# Altium schematic sheet Robot_Sheet1.SchDoc
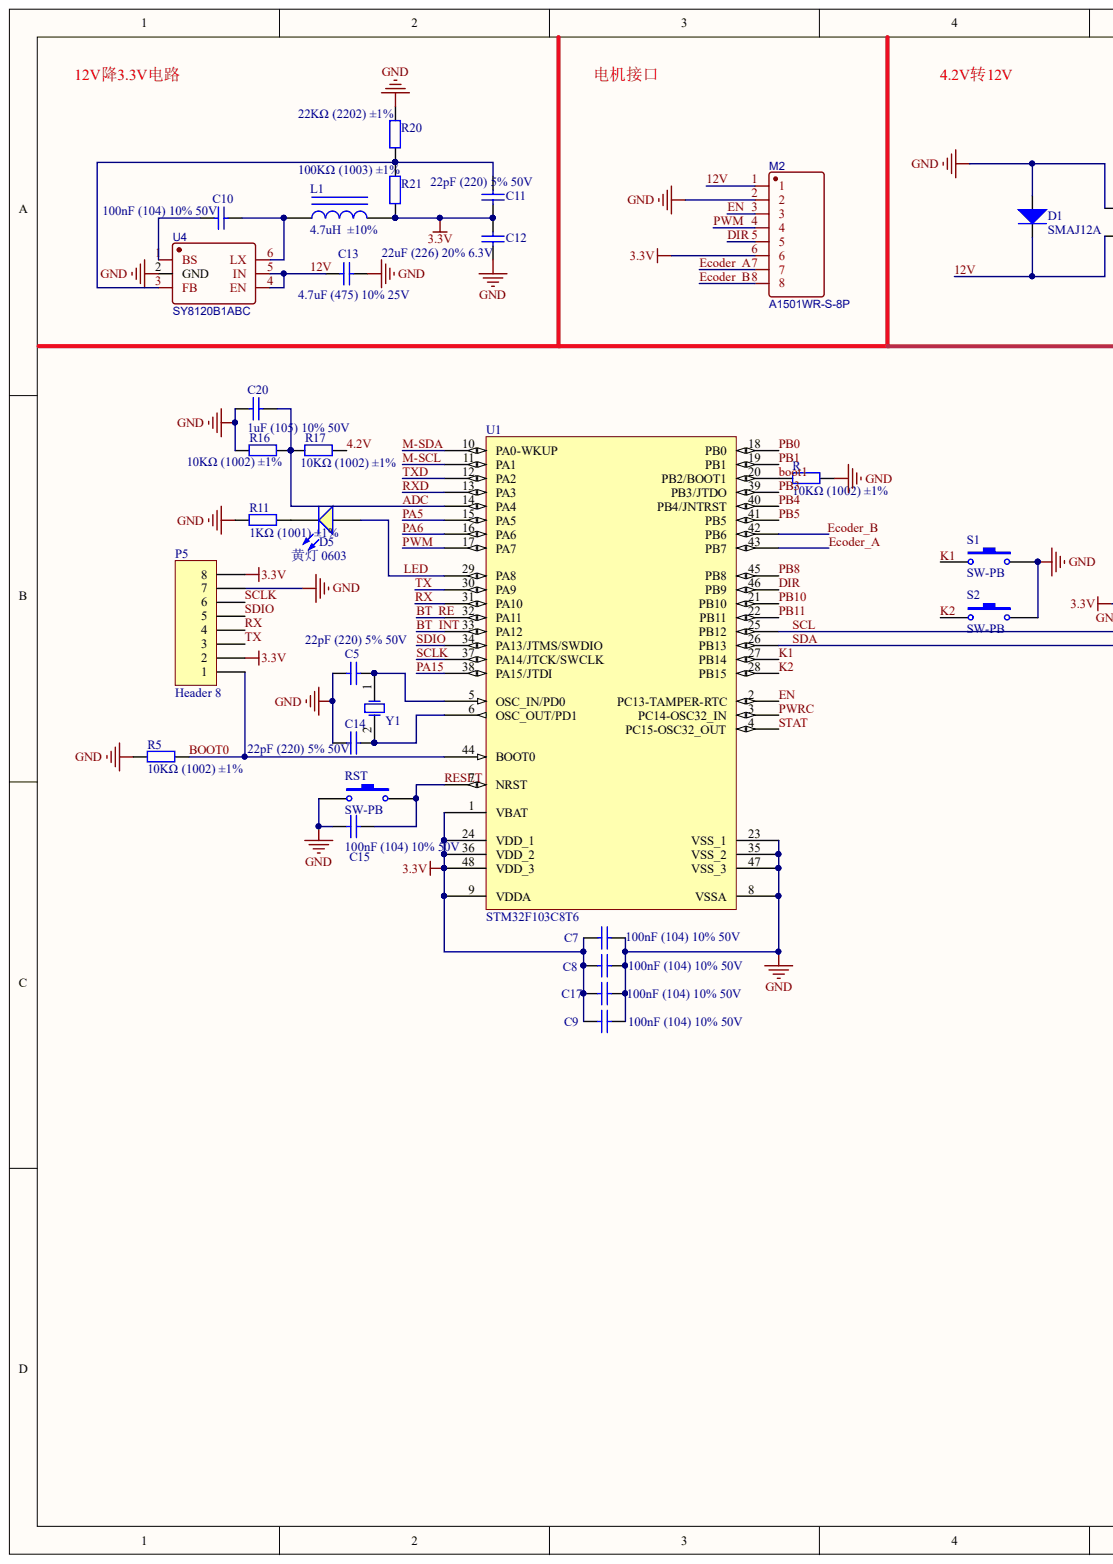
[diagram p0: Robot_Sheet1.SchDoc, left]
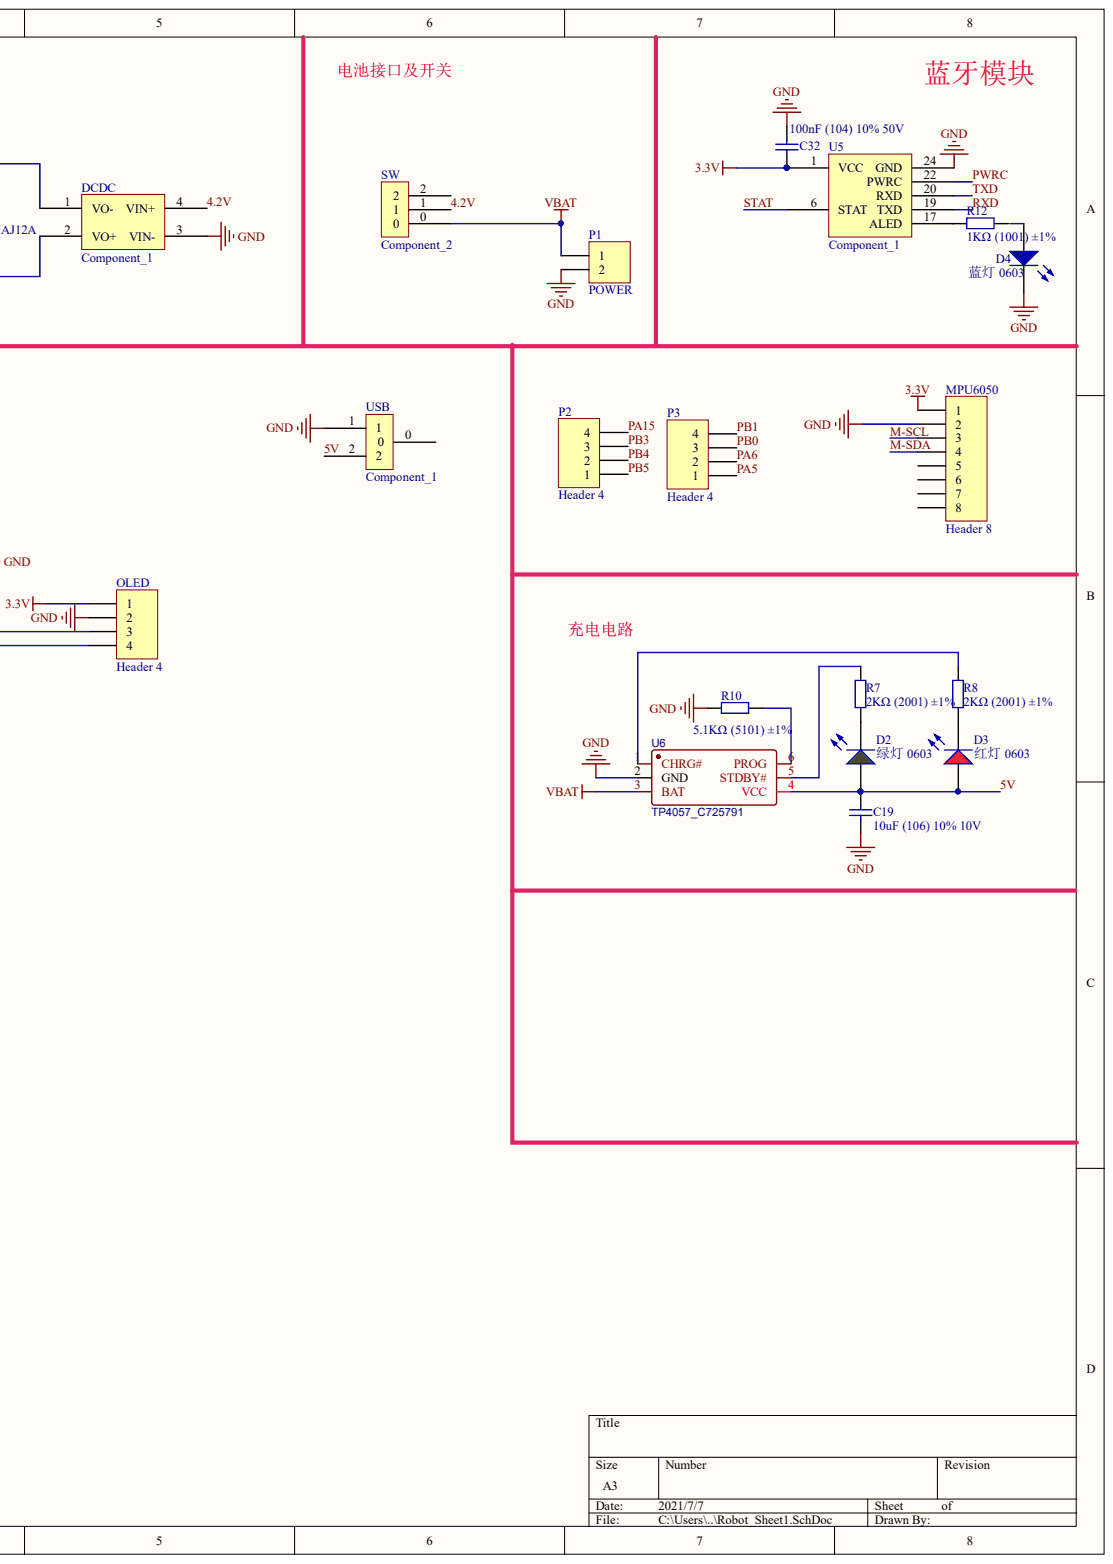
[diagram p1: Robot_Sheet1.SchDoc, right]

## Parts
C5  22pF (220) 5% 50V  [0603_C]
C7  100nF (104) 10% 50V  [0603_C]
C8  100nF (104) 10% 50V  [0603_C]
C9  100nF (104) 10% 50V  [0603_C]
C10  100nF (104) 10% 50V  [0603_C]
C11  22pF (220) 5% 50V  [0603_C]
C12  22uF (226) 20% 6.3V  [0603_C]
C13  4.7uF (475) 10% 25V  [0805_C]
C14  22pF (220) 5% 50V  [0603_C]
C15  100nF (104) 10% 50V  [0603_C]
C17  100nF (104) 10% 50V  [0603_C]
C19  10uF (106) 10% 10V  [0603_C]
C20  1uF (105) 10% 50V  [0603_C]
C32  100nF (104) 10% 50V  [0603_C]
D1  Type: SMAJ12A  [D-1206]  (hidden comment: TVS)
D2  绿灯 0603  [0603_LED_S1]
D3  红灯 0603  Package: 0603  [0603_LED_S1]
D4  蓝灯 0603  Package: 0603  [0603_LED_S1]
D5  黄灯 0603  Package: 0603  [0603_LED_S1]
DCDC  Component_1  [DM28]
L1  4.7uH  ±10%  [1206_L]
M2  A1501WR-S-8P  [CONN-TH_A1501WR-S-8P]
MPU6050  Header 8  [HDR1X8]
OLED  Header 4  [HDR1X4]
P1  POWER  [HDR1X2]
P2  Header 4  [HDR1X4]
P3  Header 4  [HDR1X4]
P5  Header 8  [HDR1X8]
R  10KΩ (1002) ±1%  [0603_R]
R5  10KΩ (1002) ±1%  [0603_R]
R7  2KΩ (2001) ±1%  [0603_R]
R8  2KΩ (2001) ±1%  [0603_R]
R10  5.1KΩ (5101) ±1%  [0603_R]
R11  1KΩ (1001) ±1%  [0603_R]
R12  1KΩ (1001) ±1%  [0603_R]
R16  10KΩ (1002) ±1%  [0603_R]
R17  10KΩ (1002) ±1%  [0603_R]
R20  22KΩ (2202) ±1%  [0603_R]
R21  100KΩ (1003) ±1%  [0603_R]
RST  SW-PB  [SW_PB2_A1]
S1  SW-PB  [SW_PB2_A1]
S2  SW-PB  [SW_PB2_A1]
SW  Component_2  [PCBComponent_1]
U1  STM32F103C8T6  [LQFP48_M]
U4  SY8120B1ABC  [SOT-23-6_L2.9-W1.6-P0.95-LS2.8-BL]
U5  Component_1  [JDY-23]
U6  TP4057_C725791  [SOT-23-6_L2.9-W1.6-P0.95-LS2.8-BR]
USB  Component_1  [PCBComponent_1]
Y1  8MHz ±20ppm 20pF  [SMD-5032_2P]  (value not drawn)

## Nets
3.3V: C7.1 C8.1 C9.1 C11.1 C12.2 C17.1 C32.1 L1.2 M2.6 MPU6050.1 OLED.1 P5.2 P5.8 R21.1 U1.1(VBAT) U1.9(VDDA) U1.24(VDD_1) U1.36(VDD_2) U1.48(VDD_3) U5.1(VCC)
GND: C5.1 C7.2 C8.2 C9.2 C12.1 C13.1 C14.1 C15.1 C17.2 C19.2 C20.1 C32.2 D1.1 D4.K DCDC.1(VO-) DCDC.3(VIN-) M2.2 MPU6050.2 OLED.2 P1.2 P5.7 R.2 R5.1 R10.1 R11.1 R16.1 R20.2 RST.1 S1.2 S2.2 U1.8(VSSA) U1.23(VSS_1) U1.35(VSS_2) U1.47(VSS_3) U4.2(GND) U5.24(GND) U6.2(GND) USB.1
VBAT: P1.1 SW.0 U6.3(BAT)
4.2V: DCDC.4(VIN+) R17.2 SW.1
5V: C19.1 D2.A D3.A U6.4(VCC) USB.2
12V: C13.2 D1.2 DCDC.2(VO+) M2.1 U4.4(EN) U4.5(IN)
ADC: C20.2 R16.2 R17.1 U1.14(PA4)
BOOT0: P5.1 R5.2 U1.44(BOOT0)
BOOT1: R.1 U1.20(PB2/BOOT1)
BT_INT: U1.33(PA12)
BT_RE: U1.32(PA11)
DIR: M2.5 U1.46(PB9)
ECODER_A: M2.7 U1.43(PB7)
ECODER_B: M2.8 U1.42(PB6)
EN: M2.3 U1.2(PC13-TAMPER-RTC)
K1: S1.1 U1.27(PB14)
K2: S2.1 U1.28(PB15)
LED: D5.A U1.29(PA8)
M-SCL: MPU6050.3 U1.11(PA1)
M-SDA: MPU6050.4 U1.10(PA0-WKUP)
PA5: P3.1 U1.15(PA5)
PA6: P3.2 U1.16(PA6)
PA15: P2.4 U1.38(PA15/JTDI)
PB0: P3.3 U1.18(PB0)
PB1: P3.4 U1.19(PB1)
PB3: P2.3 U1.39(PB3/JTDO)
PB4: P2.2 U1.40(PB4/JNTRST)
PB5: P2.1 U1.41(PB5)
PB8: U1.45(PB8)
PB10: U1.21(PB10)
PB11: U1.22(PB11)
PWM: M2.4 U1.17(PA7)
PWRC: U1.3(PC14-OSC32_IN) U5.22(PWRC)
RESET: C15.2 RST.2 U1.7(NRST)
RX: P5.4 U1.31(PA10)
RXD: U1.13(PA3) U5.19(TXD)
SCL: OLED.3 U1.25(PB12)
SCLK: P5.6 U1.37(PA14/JTCK/SWCLK)
SDA: OLED.4 U1.26(PB13)
SDIO: P5.5 U1.34(PA13/JTMS/SWDIO)
STAT: U1.4(PC15-OSC32_OUT) U5.6(STAT)
TX: P5.3 U1.30(PA9)
TXD: U1.12(PA2) U5.20(RXD)
NetC5_2: C5.2 U1.5(OSC_IN/PD0) Y1.1
NetC10_1: C10.1 L1.1 U4.6(LX)
NetC10_2: C10.2 U4.1(BS)
NetC11_2: C11.2 R20.1 R21.2 U4.3(FB)
NetC14_2: C14.2 U1.6(OSC_OUT/PD1) Y1.2
NetD2_K: D2.K R7.1
NetD3_K: D3.K R8.1
NetD5_K: D5.K R11.2
NetR7_2: R7.2 U6.5(STDBY#)
NetR8_2: R8.2 U6.1(CHRG#)
NetR10_2: R10.2 U6.6(PROG)

Not connected: D4 A; MPU6050 5 6 7 8; R12 1 2; SW 2; U5 17(ALED); USB 0

## Library data of the parts
C5 C11 C14 = 0603 22pF (220) 5% 50V: 贴片电容; Component Kind: Standard; ComponentLink1Description: 供应商链接; ComponentLink1URL: http://www.szlcsc.com/product/details_2005.html; Library Name: Miscellaneous Devices LC.IntLib; Package: 0603; Supplier: LC; SuppliersPartNumber: C1653
C7-C10 C15 C17 C32 = 0603 100nF (104) 10% 50V: 贴片电容; Component Kind: Standard; ComponentLink1Description: 供应商链接; ComponentLink1URL: http://www.szlcsc.com/product/details_15331.html; Library Name: Miscellaneous Devices LC.IntLib; Package: 0603; Supplier: LC; SuppliersPartNumber: C14663
C12 = 0603 22uF (226) 20% 6.3V: 贴片电容; Component Kind: Standard; ComponentLink1Description: 供应商链接; ComponentLink1URL: http://www.szlcsc.com/product/details_60514.html; Library Name: Miscellaneous Devices LC.IntLib; Package: 0603; Supplier: LC; SuppliersPartNumber: C59461
C13 = 0805 4.7uF (475) 10% 25V: 贴片电容; Component Kind: Standard; ComponentLink1Description: 供应商链接; ComponentLink1URL: http://www.szlcsc.com/product/details_2131.html; Library Name: Miscellaneous Devices LC.IntLib; Package: 0805; Supplier: LC; SuppliersPartNumber: C1779
C19 = 0603 10uF (106) 10% 10V: 贴片电容; Component Kind: Standard; ComponentLink1Description: 供应商链接; ComponentLink1URL: http://www.szlcsc.com/product/details_20411.html; Library Name: Miscellaneous Devices LC.IntLib; Package: 0603; Supplier: LC; SuppliersPartNumber: C19702
C20 = 0603 1uF (105) 10% 50V: 贴片电容; Component Kind: Standard; ComponentLink1Description: 供应商链接; ComponentLink1URL: http://www.szlcsc.com/product/details_16531.html; Library Name: Miscellaneous Devices LC.IntLib; Package: 0603; Supplier: LC; SuppliersPartNumber: C15849
D1 = TVS: TVS保护; Code_JEDEC: DO-214-AB; Component Kind: Standard; LatestRevisionDate: 17-Jul-2002; LatestRevisionNote: Re-released for DXP Platform.; PackageDocument: Sep-1996; PackageReference: SMC; Published: 8-Jun-2000; Library Name: Fszhang.IntLib; Publisher: Altium Limited
D2 = 0603 绿灯: 发光二极管; Component Kind: Standard; ComponentLink1Description: 供应商链接; ComponentLink1URL: http://www.szlcsc.com/product/details_73152.html; Library Name: Miscellaneous Devices LC.IntLib; Package: 0603; Supplier: LC; SuppliersPartNumber: C72043
D3 = 0603 红灯: 发光二极管; Component Kind: Standard; ComponentLink1Description: 供应商链接; ComponentLink1URL: http://www.szlcsc.com/product/details_2659.html; Library Name: Miscellaneous Devices LC.IntLib; Supplier: LC; SuppliersPartNumber: C2286
D4 = 0603 蓝灯: 发光二极管; Component Kind: Standard; ComponentLink1Description: 供应商链接; ComponentLink1URL: http://www.szlcsc.com/product/details_73150.html; Library Name: Miscellaneous Devices LC.IntLib; Supplier: LC; SuppliersPartNumber: C72041
D5 = 0603 黄灯: 发光二极管; Component Kind: Standard; ComponentLink1Description: 供应商链接; ComponentLink1URL: http://www.szlcsc.com/product/details_73147.html; Library Name: Miscellaneous Devices LC.IntLib; Supplier: LC; SuppliersPartNumber: C72038
L1 = 1206 4.7uH  10%: 贴片电感; Component Kind: Standard; ComponentLink1Description: 供应商链接; ComponentLink1URL: http://www.szlcsc.com/product/details_1401.html; Library Name: Miscellaneous Devices LC.IntLib; Package: 1206; Supplier: LC; SuppliersPartNumber: C1049
M2 = A1501WR-S-8P: BOM_Manufacturer: Changjiang Connectors; Contributor: LCSC; BOM_Manufacturer Part: A1501WR-S-8P; BOM_Supplier: LCSC; BOM_Supplier Part: C225173; Component Kind: Standard; Library Name: ZH1.5.IntLib; spicePre: C; spiceSymbolName: A1501WR-S-8P
MPU6050 P5 = Header 8: Header, 8-Pin; Component Kind: Standard; LatestRevisionDate: 17-Jul-2002; LatestRevisionNote: Re-released for DXP Platform.; Library Name: Miscellaneous Connectors.IntLib; Signal Integrity: Connector; Publisher: Altium Limited
OLED P2 P3 = Header 4: Header, 4-Pin; Component Kind: Standard; LatestRevisionDate: 17-Jul-2002; LatestRevisionNote: Re-released for DXP Platform.; Library Name: Miscellaneous Connectors.IntLib; Signal Integrity: Connector; Publisher: Altium Limited
P1 = Header 2: Header, 2-Pin; Component Kind: Standard; LatestRevisionDate: 17-Jul-2002; LatestRevisionNote: Re-released for DXP Platform.; Library Name: Miscellaneous Connectors.IntLib; Signal Integrity: Connector; Publisher: Altium Limited
R R5 R16 R17 = 0603 10KΩ (1002) 1%: 贴片电阻; Component Kind: Standard; ComponentLink1Description: 供应商链接; ComponentLink1URL: http://www.szlcsc.com/product/details_26547.html; Library Name: Miscellaneous Devices LC.IntLib; Package: 0603; Supplier: LC; SuppliersPartNumber: C25804
R7 R8 = 0603 2KΩ (2001) 1%: 贴片电阻; Component Kind: Standard; ComponentLink1Description: 供应商链接; ComponentLink1URL: http://www.szlcsc.com/product/details_23702.html; Library Name: Miscellaneous Devices LC.IntLib; Package: 0603; Supplier: LC; SuppliersPartNumber: C22975
R10 = 0603 5.1KΩ (5101) 1%: 贴片电阻; Component Kind: Standard; ComponentLink1Description: 供应商链接; ComponentLink1URL: http://www.szlcsc.com/product/details_23913.html; Library Name: Miscellaneous Devices LC.IntLib; Package: 0603; Supplier: LC; SuppliersPartNumber: C23186
R11 R12 = 0603 1KΩ (1001) 1%: 贴片电阻; Component Kind: Standard; ComponentLink1Description: 供应商链接; ComponentLink1URL: http://www.szlcsc.com/product/details_21904.html; Library Name: Miscellaneous Devices LC.IntLib; Package: 0603; Supplier: LC; SuppliersPartNumber: C21190
R20 = 0603 22KΩ (2202) 1%: 贴片电阻; Component Kind: Standard; ComponentLink1Description: 供应商链接; ComponentLink1URL: http://www.szlcsc.com/product/details_32812.html; Library Name: Miscellaneous Devices LC.IntLib; Package: 0603; Supplier: LC; SuppliersPartNumber: C31850
R21 = 0603 100KΩ (1003) 1%: 贴片电阻; Component Kind: Standard; ComponentLink1Description: 供应商链接; ComponentLink1URL: http://www.szlcsc.com/product/details_26546.html; Library Name: Miscellaneous Devices LC.IntLib; Package: 0603; Supplier: LC; SuppliersPartNumber: C25803
RST S1 S2 = SW-PB: 微动开关; Component Kind: Standard; LatestRevisionDate: 17-Jul-2002; LatestRevisionNote: Re-released for DXP Platform.; PackageReference: SPST-2; Published: 24-Mar-1999; Library Name: Fszhang.IntLib; Publisher: Altium Limited
SW = Component_1: Component Kind: Standard; Library Name: SW.SchLib
U1 = STM32F103C8T6: STM32 ARM-based 32-bit MCU with 64 Kbytes Flash, 48-pin LQFP, Industrial Temperature; Component Kind: Standard; ComponentLink1Description: Manufacturer Link; ComponentLink1URL: www.st.com/stm32; ComponentLink2Description: Datasheet; ComponentLink2URL: http://www.st.com/stonline/products/literature/ds/13587.pdf; DatasheetVersion: rev6, Mar-2008; Library Name: ST Microcontroller 32-Bit STM32.IntLib; PackageDescription: 48-Pin Low-Profile Quad Flat Pack, 7 x 7 mm Body, 0.5 mm Pitch (LQFP48); PackageReference: LQFP48; PackageVersion: Mar-2008; Published: 30-May-2008; Publisher: Altium Limited
U4 = SY8120B1ABC: BOM_Manufacturer: Silergy; SMT Type: Extend; BOM_LCSC Assembly: Yes; BOM_Manufacturer Part: SY8120B1ABC; BOM_Supplier: LCSC; BOM_Supplier Part: C88474; Component Kind: Standard; Library Name: SY8120.IntLib; spicePre: X; spiceSymbolName: SY8120B1ABC
U6 = TP4057_C725791: BOM_Manufacturer: UMW(Youtai Semiconductor Co., Ltd.); BOM_Manufacturer Part: TP4057; Contributor: LCSC; BOM_Supplier: LCSC; BOM_Supplier Part: C725791; Component Kind: Standard; Library Name: tp4057.IntLib; spicePre: U; spiceSymbolName: TP4057_C725791
Y1 = SMD-5032_2P 8MHz 20ppm 20pF: 贴片晶振; Component Kind: Standard; ComponentLink1Description: 供应商链接; ComponentLink1URL: http://www.szlcsc.com/product/details_117214.html; Library Name: Miscellaneous Devices LC.IntLib; Package: SMD-5032_2P; Supplier: LC; SuppliersPartNumber: C115962
DCDC U5 USB = Component_1: Component Kind: Standard
  DCDC: Library Name: DM27.IntLib
  U5: Library Name: JDY-23.IntLib
  USB: Library Name: microusb.IntLib
